# Altium schematic sheet display.SchDoc
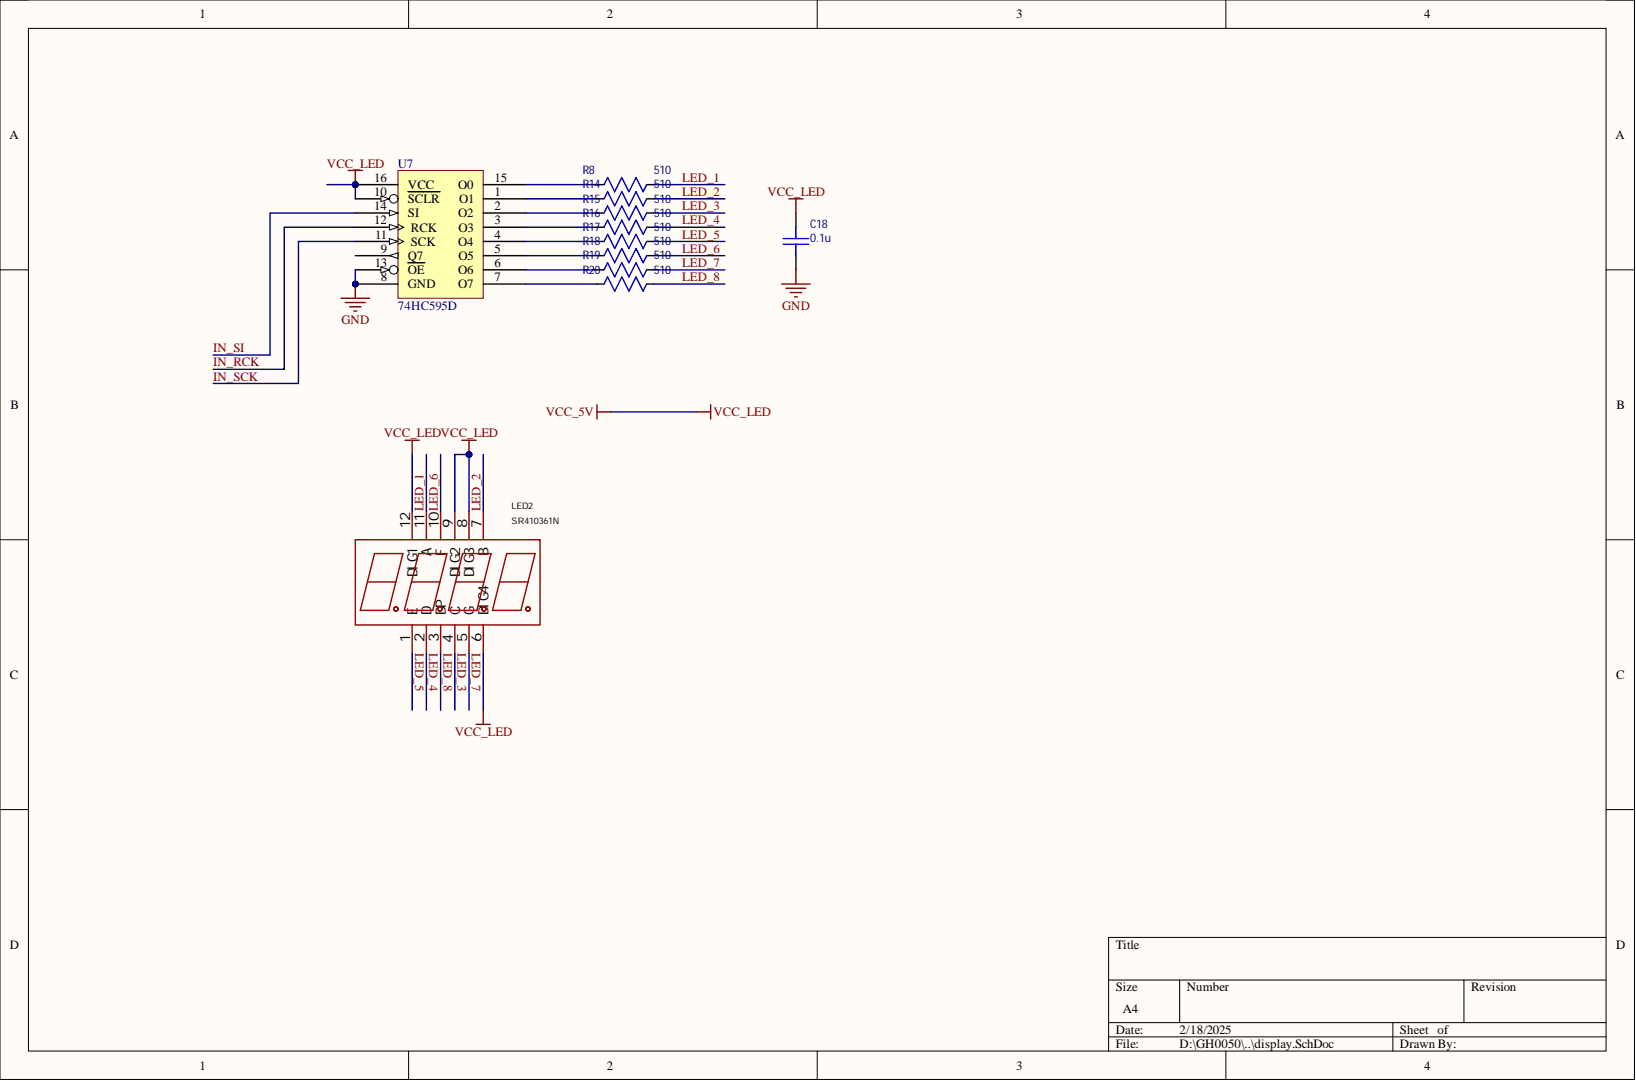
[diagram p0: display.SchDoc]

## Parts
C18  value 0.1u  [CAPC1608X092N_0603]  (hidden comment: Capacitor_0603)
LED2  SR410361N  [LED-SEG-TH_12P-L30.0-W14.0-P2.54-S10.26-BL]
R8  510  [RESC1608X055N_0603]
R14  510  [RESC1608X055N_0603]
R15  510  [RESC1608X055N_0603]
R16  510  [RESC1608X055N_0603]
R17  510  [RESC1608X055N_0603]
R18  510  [RESC1608X055N_0603]
R19  510  [RESC1608X055N_0603]
R20  510  [RESC1608X055N_0603]
U7  74HC595D  [SOIC-16_L9.9-W3.9-P1.27-LS6.0-BL]

## Nets
GND: C18.2 U7.8(GND) U7.13(OE)
VCC_5V: C18.1 LED2.6(DIG4) LED2.8(DIG3) LED2.9(DIG2) LED2.12(DIG1) U7.10(SCLR) U7.16(VCC)
IN_RCK: U7.12(RCK)
IN_SCK: U7.11(SCK)
IN_SI: U7.14(SI)
LED_1: LED2.11(A) R8.1
LED_2: LED2.7(B) R14.1
LED_3: LED2.4(C) R15.1
LED_4: LED2.2(D) R16.1
LED_5: LED2.1(E) R17.1
LED_6: LED2.10(F) R18.1
LED_7: LED2.5(G) R19.1
LED_8: LED2.3(DP) R20.1
NetR8_2: R8.2 U7.15(O0)
NetR14_2: R14.2 U7.1(O1)
NetR15_2: R15.2 U7.2(O2)
NetR16_2: R16.2 U7.3(O3)
NetR17_2: R17.2 U7.4(O4)
NetR18_2: R18.2 U7.5(O5)
NetR19_2: R19.2 U7.6(O6)
NetR20_2: R20.2 U7.7(O7)

Not connected: U7 9(Q7)

## Library data of the parts
C18 = Capacitor_0603: Capacitor, MLCC; Manufacturer: KEMET; PartNumber: C0603C104M5RACTU; TempCo: [tempco]; Tolerance: [tol]; Voltage Rating: [voltage]; Component Kind: Standard; ComponentLink1Description: Datasheet; Package: 0603; SupplierDescription: CAP CER 0.1UF 50V X7R 0603; Library Name: Karl.SchLib
LED2 = SR410361N: Manufacturer: ARKLED(方舟); Add into BOM: yes; Digit/Alpha Size(inch): 0.36; LED Polarity: 共阳极; Luminous Color: 红色; Number of Characters: 4位; Component Kind: Standard; Convert to PCB: yes; Datasheet: https://atta.szlcsc.com/upload/public/pdf/source/20171014/C132660_15079754140001038126.pdf; Device: SR410361N; JLCPCB Part Class: Extended Part; LCSC Part Name: 4位0.36英寸红色数码管 共阳极; Manufacturer Part: SR410361N; PCB3D: LED-SEG-TH_12P-L30.0-W14.0-H7.2-P2.54-S10.26-BL; Supplier: LCSC; Supplier Footprint: 插件; Supplier Part: C132660; Symbol: SR410361N
R8 R14-R20 = Resistor_0603: Resistor, Standard; Manufacturer: Yageo; PartNumber: RC0603FR-1075KL; Power Rating: [power]; TempCo: [tempco]; Tolerance: tol; Component Kind: Standard; ComponentLink1Description: Datasheet; Package: 0603; SupplierDescription: RES SMD 75K OHM 1% 1/10W 0603; Value: 510; Library Name: Karl.SchLib
U7 = 74HC595: Component Kind: Standard; Library Name: DI16.SchLib
